# Altium schematic sheet CC3200底板.SchDoc
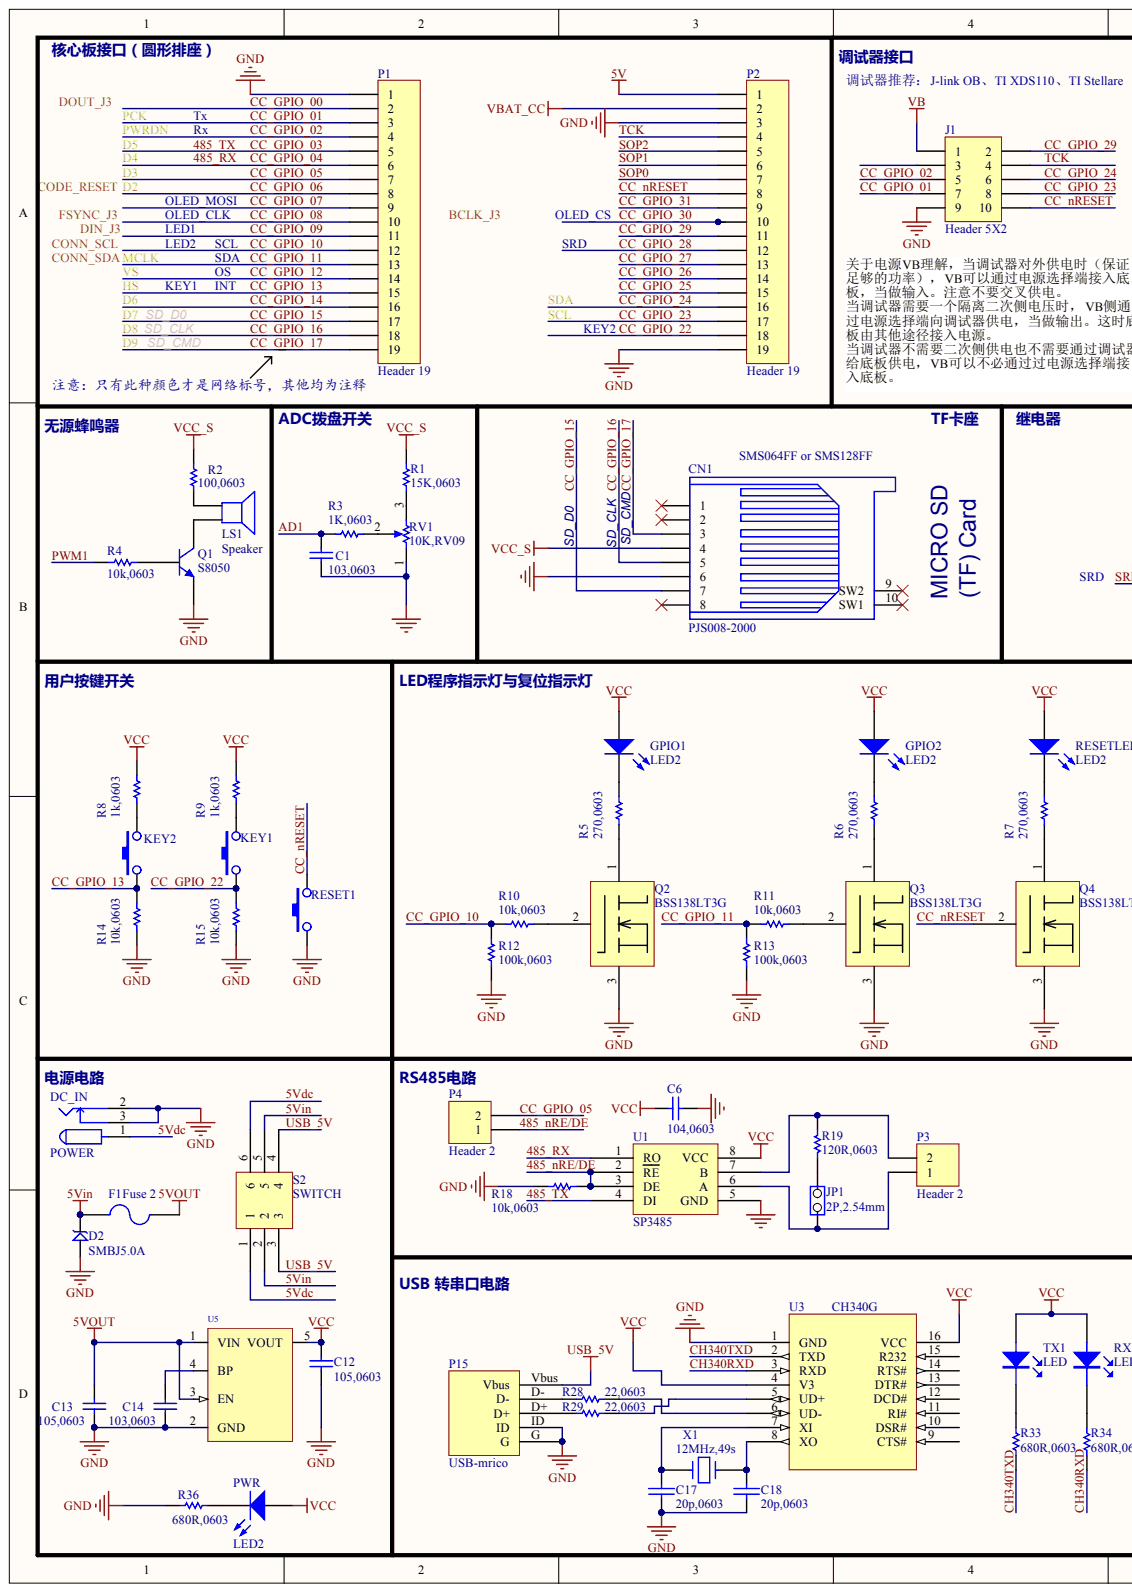
[diagram p0: CC3200底板.SchDoc, left]
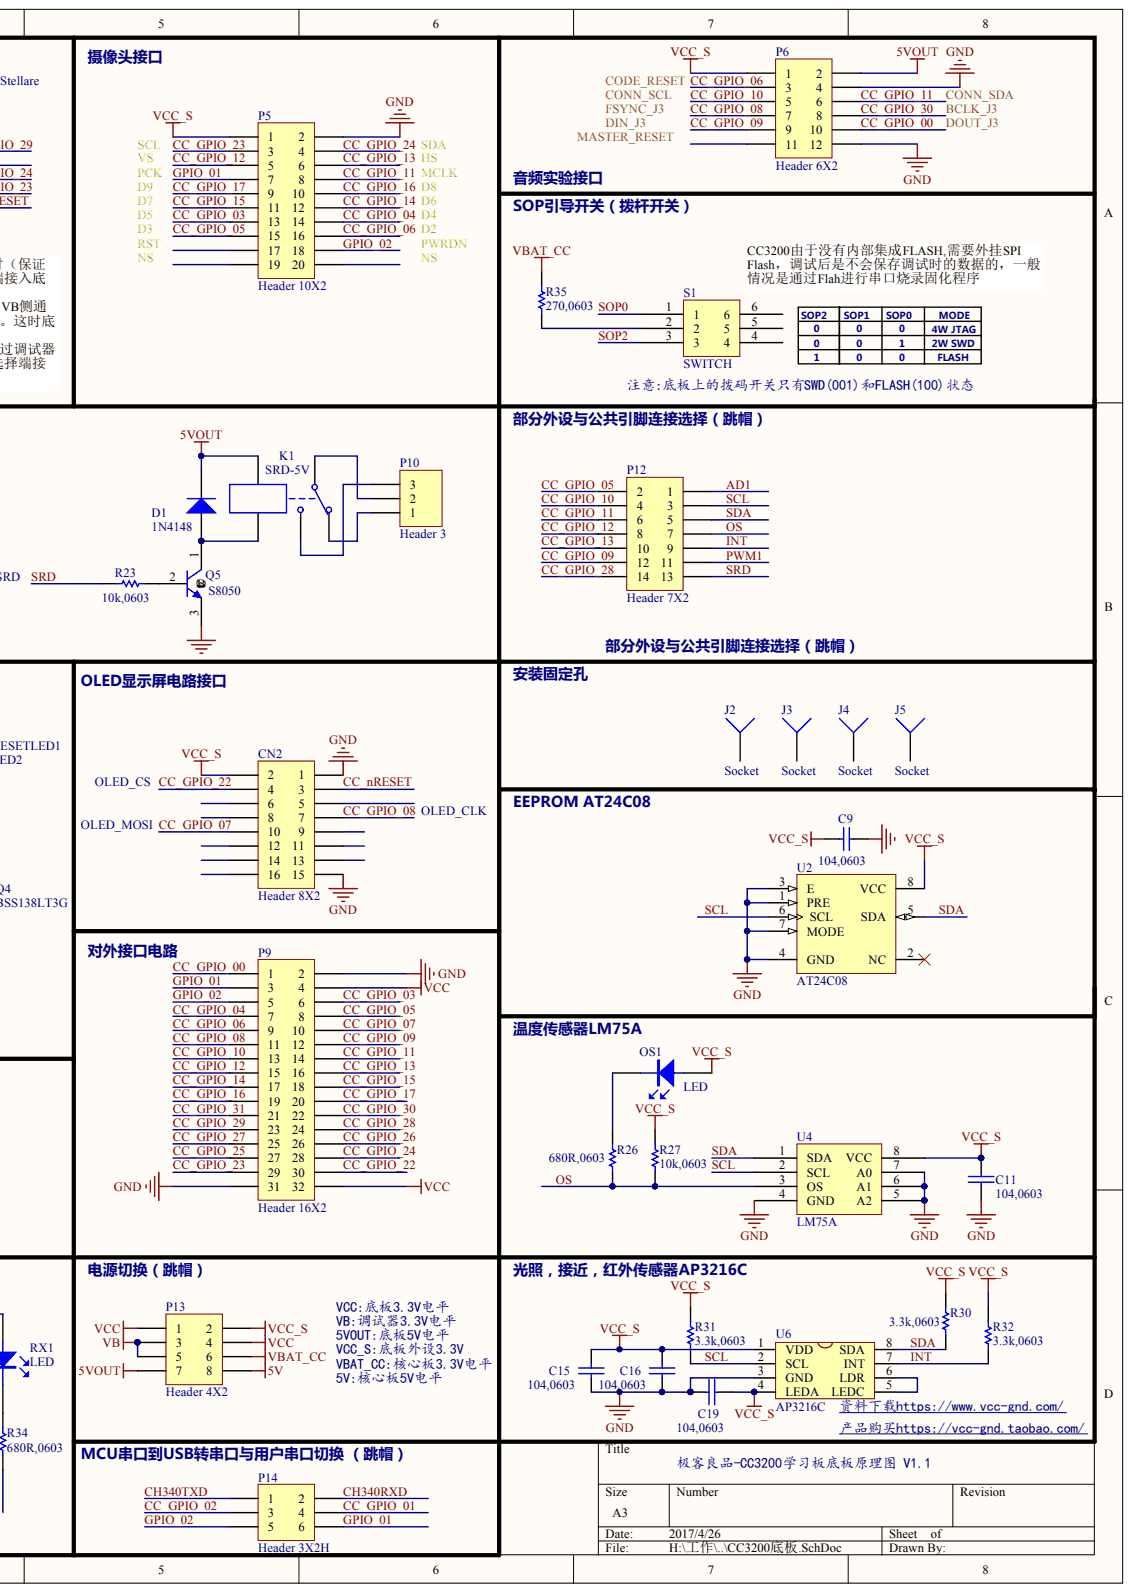
[diagram p1: CC3200底板.SchDoc, right]

## Parts
C1  103,0603
C6  104,0603
C9  104,0603
C11  104,0603
C12  105,0603
C13  105,0603
C14  103,0603
C15  104,0603
C16  104,0603
C17  20p,0603
C18  20p,0603
C19  104,0603
CN1  PJS008-2000
CN2  Header 8X2
D1  1N4148
D2  SMBJ5.0A
DC_IN  POWER
F1  Fuse 2
GPIO1  LED2
GPIO2  LED2
J1  Header 5X2
J2  Socket
J3  Socket
J4  Socket
J5  Socket
JP1  2P,2.54mm
K1  SRD-5V
KEY1  (no value)
KEY2  (no value)
LS1  Speaker
OS1  LED
P1  Header 19
P2  Header 19
P3  Header 2
P4  Header 2
P5  Header 10X2
P6  Header 6X2
P9  Header 16X2
P10  Header 3
P12  Header 7X2
P13  Header 4X2
P14  Header 3X2H
P15  USB-mrico
PWR  LED2
Q1  S8050
Q2  BSS138LT3G
Q3  BSS138LT3G
Q4  BSS138LT3G
Q5  S8050
R1  15K,0603
R2  100,0603
R3  1K,0603
R4  10k,0603
R5  270,0603
R6  270,0603
R7  270,0603
R8  1k,0603
R9  1k,0603
R10  10k,0603
R11  10k,0603
R12  100k,0603
R13  100k,0603
R14  10k,0603
R15  10k,0603
R18  10k,0603
R19  120R,0603
R23  10k,0603
R26  680R,0603
R27  10k,0603
R28  22,0603
R29  22,0603
R30  3.3k,0603
R31  3.3k,0603
R32  3.3k,0603
R33  680R,0603
R34  680R,0603
R35  270,0603
R36  680R,0603
RESET1  (no value)
RESETLED1  LED2
RV1  10K,RV09
RX1  LED
S1  SWITCH
S2  SWITCH
TX1  LED
U1  SP3485
U2  AT24C08
U3  CH340G
U4  LM75A
U5  RT9193  (value not drawn)
U6  AP3216C
X1  12MHz,49s

## Nets
5V: P2.1 P13.8
5VOUT: C13.1 D1.2 F1.2 K1.4 P6.2 P13.7 U5.1(VIN) U5.3(EN)
GND: C1.1 C6.2 C9.1 C11.2 C12.2 C13.2 C14.2 C15.2 C16.2 C17.2 C18.2 C19.1 CN1.6 CN2.1 CN2.15 D2.1 DC_IN.2 DC_IN.3 J1.9 P1.1 P2.3 P2.19 P5.2 P6.4 P6.12 P9.2 P9.31 P15.G P15.ID Q1.3 Q2.3 Q3.3 Q4.3 Q5.3 R12.1 R13.1 R14.2 R15.2 R18.2 R36.1 RESET1.2 RV1.1 U1.5(GND) U2.1(PRE) U2.3(E) U2.4(GND) U2.7(MODE) U3.1(GND) U4.4(GND) U4.5(A2) U4.6(A1) U4.7(A0) U5.2(GND) U6.3(GND)
VB: J1.1 P13.3 P13.5
VBAT_CC: P2.2 P13.6 R35.2
VCC: C6.1 C12.1 GPIO1.1 GPIO2.1 P9.4 P9.32 P13.1 P13.4 PWR.1 R8.1 R9.1 RESETLED1.1 RX1.A TX1.A U1.8(VCC) U3.4(V3) U3.16(VCC) U5.5(VOUT)
VCC_S: C9.2 C11.1 C15.1 C16.1 C19.2 CN1.4 CN2.2 OS1.A P5.1 P6.1 P13.2 R1.2 R2.1 R27.2 R30.2 R31.2 R32.2 U2.8(VCC) U4.8(VCC) U6.1(VDD) U6.4(LEDA)
5VDC: DC_IN.1 S2.1 S2.6
5VIN: D2.2 F1.1 S2.2 S2.5
485_NRE/DE: P4.1 R18.1 U1.2(RE) U1.3(DE)
485_RX: P1.6 P5.14 P9.7 U1.1(RO)
485_TX: P1.5 P5.13 P9.6 U1.4(DI)
AD1: C1.2 P12.1 R3.1
CC_GPIO_00: P1.2 P6.10 P9.1
CC_GPIO_01: J1.7 P1.3 P14.4
CC_GPIO_02: J1.5 P1.4 P14.3
CC_GPIO_05: P1.7 P4.2 P5.15 P9.8 P12.2
CC_GPIO_06: P1.8 P5.16 P6.3 P9.9
CC_GPIO_07: CN2.10 P1.9 P9.10
CC_GPIO_08: CN2.7 P1.10 P6.7 P9.11
CC_GPIO_09: P1.11 P6.9 P9.12 P12.12
CC_GPIO_10: P1.12 P6.5 P9.13 P12.4 R10.1 R12.2
CC_GPIO_11: P1.13 P5.8 P6.6 P9.14 P12.6 R11.1 R13.2
CC_GPIO_12: P1.14 P5.5 P9.15 P12.8
CC_GPIO_13: KEY2.2 P1.15 P5.6 P9.16 P12.10 R14.1
CC_GPIO_14: P1.16 P5.12 P9.17
CC_GPIO_15: CN1.7 P1.17 P5.11 P9.18
CC_GPIO_16: CN1.5 P1.18 P5.10 P9.19
CC_GPIO_17: CN1.3 P1.19 P5.9 P9.20
CC_GPIO_22: CN2.4 KEY1.2 P2.18 P9.30 R15.1
CC_GPIO_23: J1.8 P2.17 P5.3 P9.29
CC_GPIO_24: J1.6 P2.16 P5.4 P9.28
CC_GPIO_25: P2.15 P9.27
CC_GPIO_26: P2.14 P9.26
CC_GPIO_27: P2.13 P9.25
CC_GPIO_28: P2.12 P9.24 P12.14
CC_GPIO_29: J1.2 P2.11 P9.23
CC_GPIO_30: P2.10 P6.8 P9.22
CC_GPIO_31: P2.9 P9.21
CC_NRESET: CN2.3 J1.10 P2.8 Q4.2 RESET1.1
CH340RXD: P14.2 R34.2 U3.3(RXD)
CH340TXD: P14.1 R33.2 U3.2(TXD)
GPIO_01: P5.7 P9.3 P14.6
GPIO_02: P5.18 P9.5 P14.5
INT: P12.9 R32.1 U6.7(INT)
OS: P12.7 R26.2 R27.1 U4.3(OS)
PWM1: P12.11 R4.1
SCL: P12.3 R31.1 U2.6(SCL) U4.2(SCL) U6.2(SCL)
SDA: P12.5 R30.1 U2.5(SDA) U4.1(SDA) U6.8(SDA)
SOP0: P2.7 S1.1
SOP1: P2.6
SOP2: P2.5 S1.3
SRD: P12.13 R23.1
TCK: J1.4 P2.4
USB_5V: P15.Vbus S2.3 S2.4
NetC14_1: C14.1 U5.4(BP)
NetC17_1: C17.1 U3.7(XI) X1.1
NetC18_1: C18.1 U3.8(XO) X1.2
NetD1_1: D1.1 K1.5 Q5.1
NetGPIO1_2: GPIO1.2 R5.1
NetGPIO2_2: GPIO2.2 R6.1
NetJP1_1: JP1.1 R19.1
NetJP1_2: JP1.2 P3.1 U1.6(A)
NetK1_1: K1.1 P10.2
NetK1_2: K1.2 P10.1
NetK1_3: K1.3 P10.3
NetKEY1_1: KEY1.1 R9.2
NetKEY2_1: KEY2.1 R8.2
NetLS1_1: LS1.1 R2.2
NetLS1_2: LS1.2 Q1.1
NetOS1_K: OS1.K R26.1
NetP3_2: P3.2 R19.2 U1.7(B)
NetP15_D+: P15.D+ R29.1
NetP15_D-: P15.D- R28.1
NetPWR_2: PWR.2 R36.2
NetQ1_2: Q1.2 R4.2
NetQ2_1: Q2.1 R5.2
NetQ2_2: Q2.2 R10.2
NetQ3_1: Q3.1 R6.2
NetQ3_2: Q3.2 R11.2
NetQ4_1: Q4.1 R7.2
NetQ5_2: Q5.2 R23.2
NetR1_1: R1.1 RV1.3
NetR3_2: R3.2 RV1.2
NetR7_1: R7.1 RESETLED1.2
NetR28_2: R28.2 U3.6(UD-)
NetR29_2: R29.2 U3.5(UD+)
NetR33_1: R33.1 TX1.K
NetR34_1: R34.1 RX1.K
NetR35_1: R35.1 S1.2
NetU6_5: U6.5(LEDC) U6.6(LDR)

Not connected: CN1 1 2 8 9(SW2) 10(SW1); CN2 5 6 8 9 11 12 13 14 16; J1 3; J2 1; J3 1; J4 1; J5 1; P5 17 19 20; P6 11; S1 4 5 6; U2 2(NC); U3 9(CTS#) 10(DSR#) 11(RI#) 12(DCD#) 13(DTR#) 14(RTS#) 15(R232)

## Library data of the parts
C1 = (no symbol name): Value: 100pF; Published: 8-Jun-2000; Revision: July-2002: Re-released for DXP Platform.; Publisher: Altium Limited
C6 = (no symbol name): Value: 100nF; Published: 8-Jun-2000; Revision: July-2002: Re-released for DXP Platform.; Publisher: Altium Limited
C9 = (no symbol name): Value: 104; Published: 8-Jun-2000; LatestRevisionDate: 17-Jul-2002; LatestRevisionNote: Re-released for DXP Platform.; Publisher: Altium Limited
C12-C14 = (no symbol name): Value: 10; Published: 8-Jun-2000; LatestRevisionDate: 17-Jul-2002; LatestRevisionNote: Re-released for DXP Platform.; Publisher: Altium Limited
R1 = (no symbol name): Value: 1K; Published: 8-Jun-2000; Revision: July-2002: Re-released for DXP Platform.; Publisher: Altium Limited
R35 R36 = (no symbol name): Value: 100; Published: 8-Jun-2000; LatestRevisionDate: 17-Jul-2002; LatestRevisionNote: Re-released for DXP Platform.; PackageReference: AXIAL-0.3; Publisher: Altium Limited
U5 = (no symbol name): ICA_MFR_NAME: RICHTEK; ICA_PARTNUM: $$2191094$$RT9193-15CU5; Part Number: TMP-13
C11 C15-C19 CN1 CN2 D1 D2 DC_IN F1 GPIO1 GPIO2 J1-J5 JP1 K1 KEY1 KEY2 LS1 OS1 P1-P6 P9 P10 P12-P15 PWR Q1-Q5 R2-R15 R18 R19 R23 R26-R34 RESET1 RESETLED1 RV1 RX1 S1 S2 TX1 U1-U4 U6 X1 = (no symbol name)
  CN2 J1-J5 P1-P6 P9 P10 P12-P14: LatestRevisionDate: 17-Jul-2002; LatestRevisionNote: Re-released for DXP Platform.
  D1: Published: 8-Jun-2000; LatestRevisionDate: 17-Jul-2002; LatestRevisionNote: Re-released for DXP Platform.; DatasheetVersion: 1997; PackageReference: DO-35; PackageDescription: Diode, Axial; Body 3.8 x 1.9 mm (LxDia. max), Lead Dia. 0.53 mm (max); Code_JEDEC: DO-204-AH; ComponentLink1Description: Manufacturer Link; ComponentLink1URL: http://www.fairchildsemi.com/; ComponentLink2Description: Datasheet; ComponentLink2URL: http://www.fairchildsemi.com/ds/1N%2F1N4148.pdf
  F1: Published: 8-Jun-2000; LatestRevisionDate: 17-Jul-2002; LatestRevisionNote: Re-released for DXP Platform.; PackageReference: PIN-W2/E2.8
  GPIO1 GPIO2 PWR RESETLED1: Published: 8-Jun-2000; LatestRevisionDate: 17-Jul-2002; LatestRevisionNote: Re-released for DXP Platform.; PackageDocument: Feb-2002; PackageReference: 3.2X1.6X1.1
  K1: Published: 24-Mar-1999; LatestRevisionDate: 17-Jul-2002; LatestRevisionNote: Re-released for DXP Platform.; PackageReference: MODULE5B
  LS1: Published: 24-Mar-1999; LatestRevisionDate: 17-Jul-2002; LatestRevisionNote: Re-released for DXP Platform.; PackageReference: PIN2
  Q1 Q5: Published: 8-Jun-2000; LatestRevisionDate: 17-Jul-2002; LatestRevisionNote: Re-released for DXP Platform.; PackageReference: TO-226; Code_JEDEC: TO-226-AA
  R2 R4-R15 R18 R19 R23 R26-R34: Published: 8-Jun-2000; Revision: July-2002: Re-released for DXP Platform.
  R3: Published: 8-Jun-2000; Revision: July-2002: Re-released for DXP Platform.; Value: 1K
  U2: Published: 24-Aug-2001; LatestRevisionDate: 06-Jun-2005; LatestRevisionNote: Stylized 3D Model Added.; DatasheetVersion: Jul-1996; PackageVersion: Jun-1998; PackageReference: PSDIP8A; PackageDescription: DIP; 8 Leads; Row Spacing 7.62 mm; Pitch 2.54 mm; ComponentLink1Description: Manufacturer Link; ComponentLink1URL: http://www.st.com/
  CN2 D1 F1 GPIO1 GPIO2 J1-J5 K1 LS1 P1-P6 P9 P10 P12-P14 PWR Q1 Q5 R2-R15 R18 R19 R23 R26-R34 RESETLED1 U2: Publisher: Altium Limited
